# Altium schematic sheet 04_fmu_mcu1.SchDoc(04_fmu_mcu1)
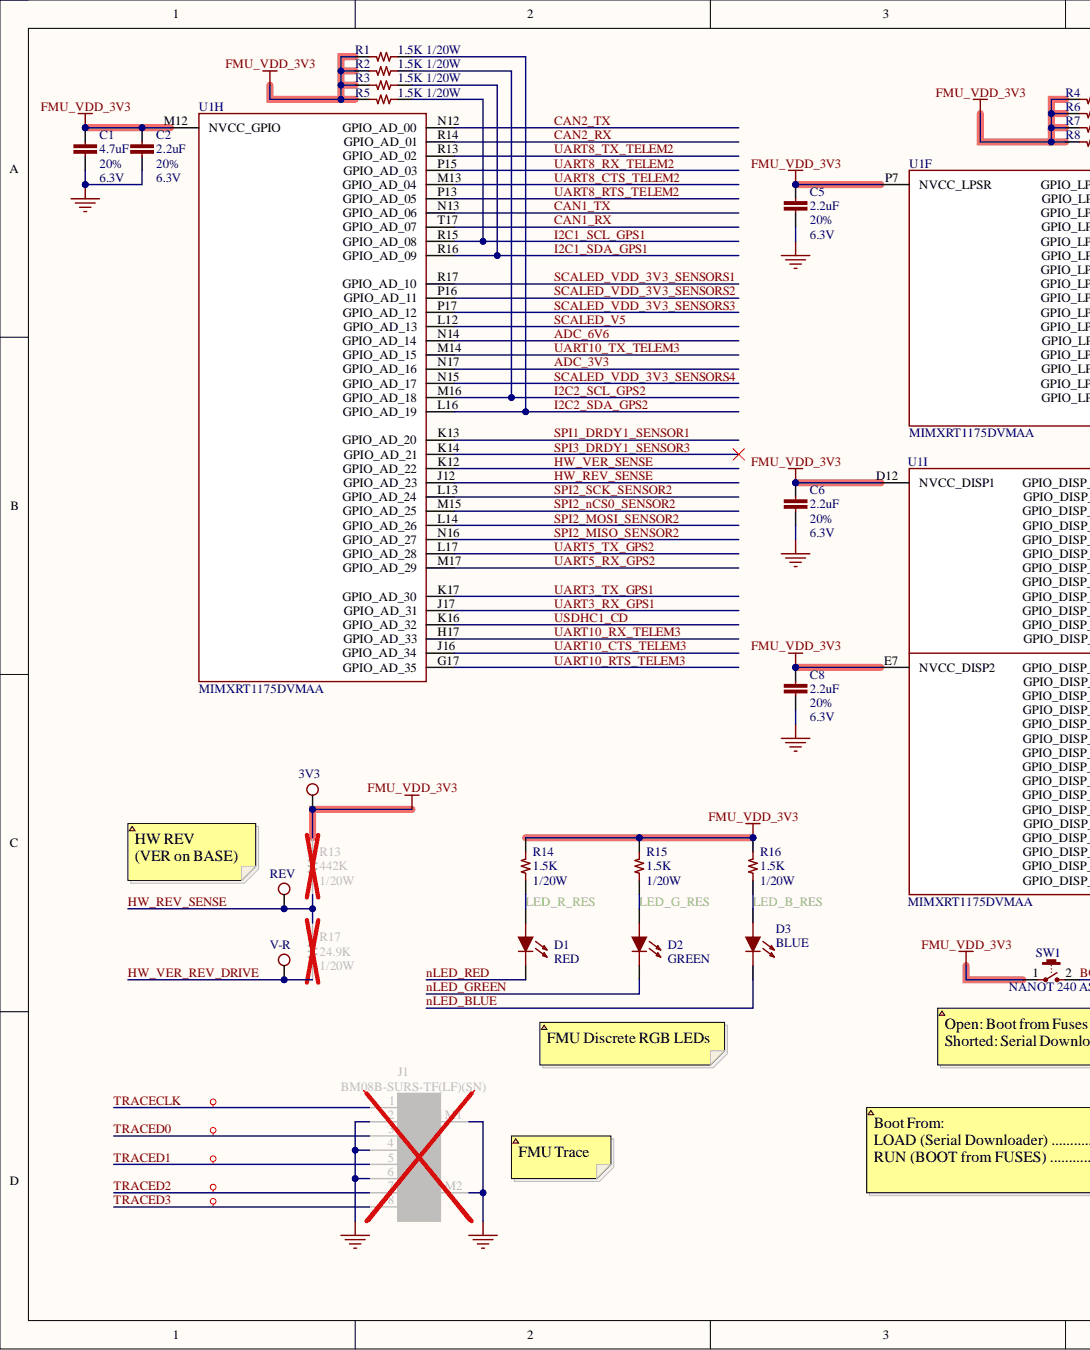
[diagram p0: 04_fmu_mcu1.SchDoc, left]
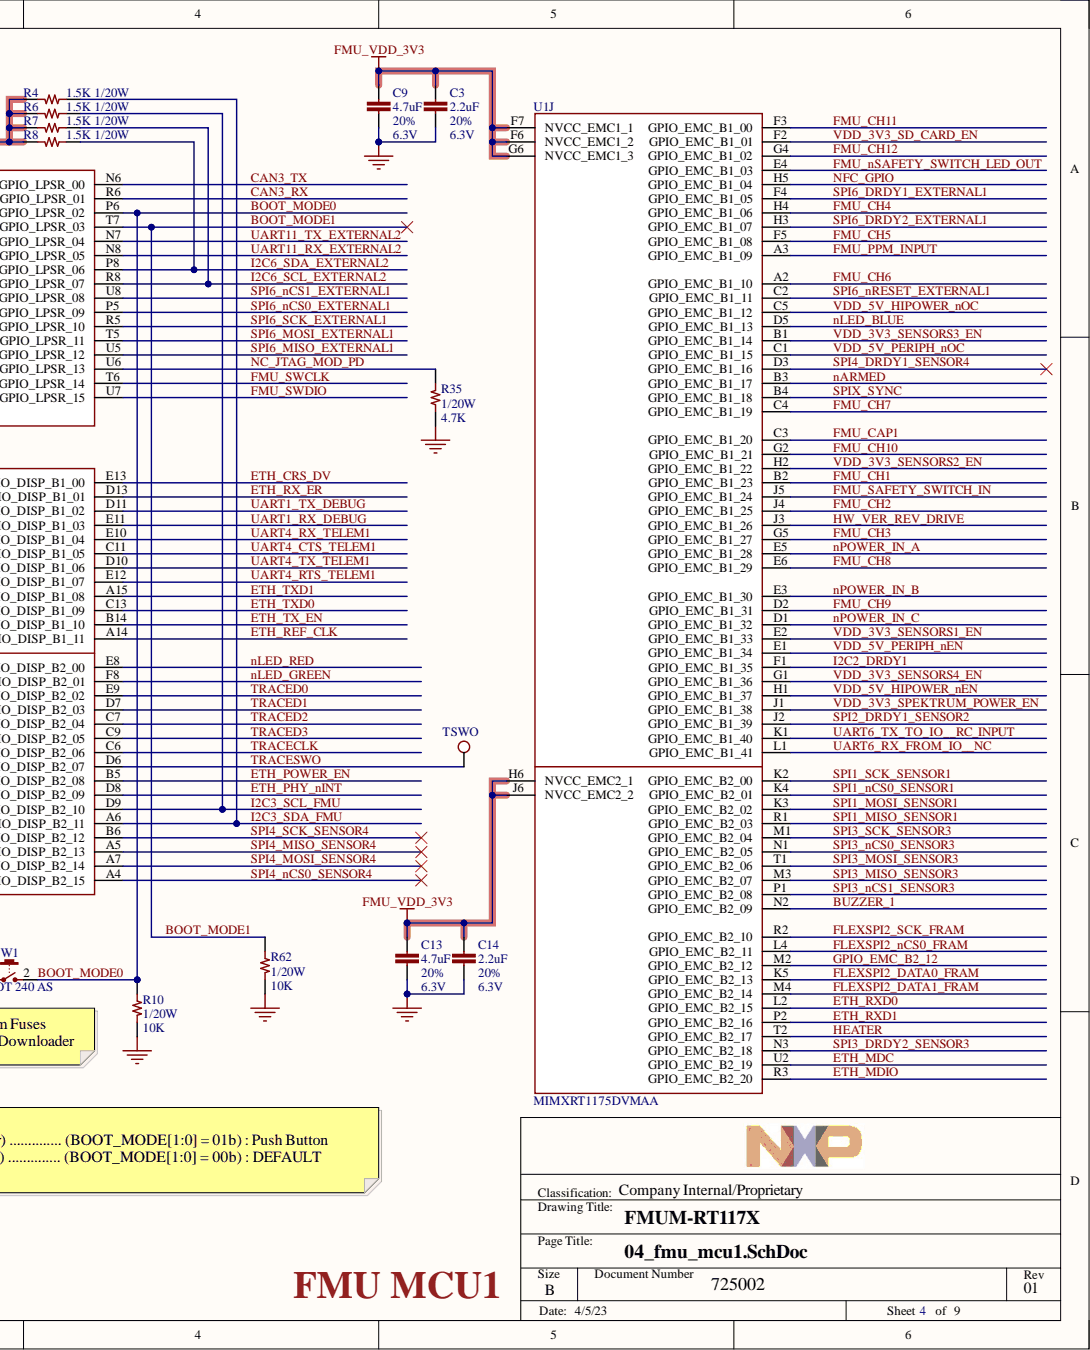
[diagram p1: 04_fmu_mcu1.SchDoc, right]

## Parts
3V3  TESTPOINT PAD SMD  [TEST_PAD_1MM]  (value not drawn)  (no BOM)
C1  value 4.7uF  Tolerance: 20%  Voltage - Rated: 6.3V  [CAPC60X30X33L15N]  (hidden comment: CAP CER 4.7uF 20% 10V X5R 0201)
C2  value 2.2uF  Tolerance: 20%  Voltage - Rated: 6.3V  [CAPC60X30X33L15N]  (hidden comment: CAP CER 2.2uF 20% 10V X5R 0201)
C3  value 2.2uF  Tolerance: 20%  Voltage - Rated: 6.3V  [CAPC60X30X33L15N]  (hidden comment: CAP CER 2.2uF 20% 10V X5R 0201)
C5  value 2.2uF  Tolerance: 20%  Voltage - Rated: 6.3V  [CAPC60X30X33L15N]  (hidden comment: CAP CER 2.2uF 20% 10V X5R 0201)
C6  value 2.2uF  Tolerance: 20%  Voltage - Rated: 6.3V  [CAPC60X30X33L15N]  (hidden comment: CAP CER 2.2uF 20% 10V X5R 0201)
C8  value 2.2uF  Tolerance: 20%  Voltage - Rated: 6.3V  [CAPC60X30X33L15N]  (hidden comment: CAP CER 2.2uF 20% 10V X5R 0201)
C9  value 4.7uF  Tolerance: 20%  Voltage - Rated: 6.3V  [CAPC60X30X33L15N]  (hidden comment: CAP CER 4.7uF 20% 10V X5R 0201)
C13  value 4.7uF  Tolerance: 20%  Voltage - Rated: 6.3V  [CAPC60X30X33L15N]  (hidden comment: CAP CER 4.7uF 20% 10V X5R 0201)
C14  value 2.2uF  Tolerance: 20%  Voltage - Rated: 6.3V  [CAPC60X30X33L15N]  (hidden comment: CAP CER 2.2uF 20% 10V X5R 0201)
D1  Color: RED  [LEDSC80X160X65L40N_RED]  (hidden comment: LED RED CLEAR 0603 SMD)
D2  Color: GREEN  [LEDSC80X160X65L40N_GRN]  (hidden comment: LED GREEN CLEAR 0603 SMD)
D3  Color: BLUE  [LEDSC80X160X65L40N_BLU]  (hidden comment: LED BLUE CLEAR 0603 SMD)
J1  Manufacturer Part Number: BM08B-SURS-TF(LF)(SN)  [JST_BM08B-SURS-TFLFSN]  (hidden comment: CONN HEADER SUR 8POS .8MM VERT SMT)
R1  value 1.5K  Power: 1/20W  [RESC60X30X33L15N]  (hidden comment: RES SMD 1.5K OHM 1% 1/20W 0201)
R2  value 1.5K  Power: 1/20W  [RESC60X30X33L15N]  (hidden comment: RES SMD 1.5K OHM 1% 1/20W 0201)
R3  value 1.5K  Power: 1/20W  [RESC60X30X33L15N]  (hidden comment: RES SMD 1.5K OHM 1% 1/20W 0201)
R4  value 1.5K  Power: 1/20W  [RESC60X30X33L15N]  (hidden comment: RES SMD 1.5K OHM 1% 1/20W 0201)
R5  value 1.5K  Power: 1/20W  [RESC60X30X33L15N]  (hidden comment: RES SMD 1.5K OHM 1% 1/20W 0201)
R6  value 1.5K  Power: 1/20W  [RESC60X30X33L15N]  (hidden comment: RES SMD 1.5K OHM 1% 1/20W 0201)
R7  value 1.5K  Power: 1/20W  [RESC60X30X33L15N]  (hidden comment: RES SMD 1.5K OHM 1% 1/20W 0201)
R8  value 1.5K  Power: 1/20W  [RESC60X30X33L15N]  (hidden comment: RES SMD 1.5K OHM 1% 1/20W 0201)
R10  value 10K  Power: 1/20W  [RESC60X30X33L15N]  (hidden comment: RES SMD 10K OHM 1% 1/20W 0201)
R13  value 442K  Power: 1/20W  [RESC60X30X33L15N]  (hidden comment: RES SMD 442K OHM 1% 1/20W 0201)
R14  value 1.5K  Power: 1/20W  [RESC60X30X33L15N]  (hidden comment: RES SMD 1.5K OHM 1% 1/20W 0201)
R15  value 1.5K  Power: 1/20W  [RESC60X30X33L15N]  (hidden comment: RES SMD 1.5K OHM 1% 1/20W 0201)
R16  value 1.5K  Power: 1/20W  [RESC60X30X33L15N]  (hidden comment: RES SMD 1.5K OHM 1% 1/20W 0201)
R17  value 24.9K  Power: 1/20W  [RESC60X30X33L15N]  (hidden comment: RES SMD 24.9K OHM 1% 1/20W 0201)
R35  value 4.7K  Power: 1/20W  [RESC60X30X33L15N]  (hidden comment: RES SMD 4.7K OHM 1% 1/20W 0201)
R62  value 10K  Power: 1/20W  [RESC60X30X33L15N]  (hidden comment: RES SMD 10K OHM 1% 1/20W 0201)
REV  TESTPOINT PAD SMD  [TEST_PAD_1MM]  (value not drawn)  (no BOM)
SW1  Manufacturer Part Number: NANOT 240 AS  [C&K_NANOT_240_AS]  (hidden comment: SWITCH SPST-NO OFF-MOM 0.02A 15V 240GF SMT)
TSWO  TESTPOINT PAD SMD  [TEST_PAD_1MM]  (value not drawn)  (no BOM)
U1  Manufacturer Part Number: MIMXRT1175DVMAA  [BGA289CP80_17X17_1400X1400X152B43N]  (hidden comment: IC MCU 32BIT EXT MEM 800MHz 289MAPBGA)  (parts F,H,I,J)
V-R  TESTPOINT PAD SMD  [TEST_PAD_1MM]  (value not drawn)  (no BOM)

## Nets
FMU_VDD_3V3: 3V3.1 C1.1 C2.1 C3.1 C5.1 C6.1 C8.1 C9.1 C13.1 C14.1 R1.1 R2.1 R3.1 R4.1 R5.1 R6.1 R7.1 R8.1 R13.1 R14.1 R15.1 R16.1 SW1.1 U1.D12(NVCC_DISP1) U1.E7(NVCC_DISP2) U1.F6(NVCC_EMC1_2) U1.F7(NVCC_EMC1_1) U1.G6(NVCC_EMC1_3) U1.H6(NVCC_EMC2_1) U1.J6(NVCC_EMC2_2) U1.M12(NVCC_GPIO) U1.P7(NVCC_LPSR)
GND: C1.2 C2.2 C3.2 C5.2 C6.2 C8.2 C9.2 C13.2 C14.2 J1.2 J1.4 J1.6 J1.M1 J1.M2 R10.1 R35.2 R62.1
ADC_3V3: U1.N17(GPIO_AD_16)
ADC_6V6: U1.N14(GPIO_AD_14)
BOOT_MODE0: R10.2 SW1.2 U1.P6(GPIO_LPSR_02)
BOOT_MODE1: R62.2 U1.T7(GPIO_LPSR_03)
BUZZER_1: U1.N2(GPIO_EMC_B2_09)
CAN1_RX: U1.T17(GPIO_AD_07)
CAN1_TX: U1.N13(GPIO_AD_06)
CAN2_RX: U1.R14(GPIO_AD_01)
CAN2_TX: U1.N12(GPIO_AD_00)
CAN3_RX: U1.R6(GPIO_LPSR_01)
CAN3_TX: U1.N6(GPIO_LPSR_00)
ETH_CRS_DV: U1.E13(GPIO_DISP_B1_00)
ETH_MDC: U1.U2(GPIO_EMC_B2_19)
ETH_MDIO: U1.R3(GPIO_EMC_B2_20)
ETH_PHY_nINT: U1.D8(GPIO_DISP_B2_09)
ETH_POWER_EN: U1.B5(GPIO_DISP_B2_08)
ETH_REF_CLK: U1.A14(GPIO_DISP_B1_11)
ETH_RX_ER: U1.D13(GPIO_DISP_B1_01)
ETH_RXD0: U1.L2(GPIO_EMC_B2_15)
ETH_RXD1: U1.P2(GPIO_EMC_B2_16)
ETH_TX_EN: U1.B14(GPIO_DISP_B1_10)
ETH_TXD0: U1.C13(GPIO_DISP_B1_09)
ETH_TXD1: U1.A15(GPIO_DISP_B1_08)
FLEXSPI2_DATA0_FRAM: U1.K5(GPIO_EMC_B2_13)
FLEXSPI2_DATA1_FRAM: U1.M4(GPIO_EMC_B2_14)
FLEXSPI2_nCS0_FRAM: U1.L4(GPIO_EMC_B2_11)
FLEXSPI2_SCK_FRAM: U1.R2(GPIO_EMC_B2_10)
FMU_CAP1: U1.C3(GPIO_EMC_B1_20)
FMU_CH1: U1.B2(GPIO_EMC_B1_23)
FMU_CH2: U1.J4(GPIO_EMC_B1_25)
FMU_CH3: U1.G5(GPIO_EMC_B1_27)
FMU_CH4: U1.H4(GPIO_EMC_B1_06)
FMU_CH5: U1.F5(GPIO_EMC_B1_08)
FMU_CH6: U1.A2(GPIO_EMC_B1_10)
FMU_CH7: U1.C4(GPIO_EMC_B1_19)
FMU_CH8: U1.E6(GPIO_EMC_B1_29)
FMU_CH9: U1.D2(GPIO_EMC_B1_31)
FMU_CH10: U1.G2(GPIO_EMC_B1_21)
FMU_CH11: U1.F3(GPIO_EMC_B1_00)
FMU_CH12: U1.G4(GPIO_EMC_B1_02)
FMU_nSAFETY_SWITCH_LED_OUT: U1.E4(GPIO_EMC_B1_03)
FMU_PPM_INPUT: U1.A3(GPIO_EMC_B1_09)
FMU_SAFETY_SWITCH_IN: U1.J5(GPIO_EMC_B1_24)
FMU_SWCLK: U1.T6(GPIO_LPSR_14)
FMU_SWDIO: U1.U7(GPIO_LPSR_15)
GPIO_EMC_B2_12: U1.M2(GPIO_EMC_B2_12)
HEATER: U1.T2(GPIO_EMC_B2_17)
HW_REV_SENSE: R13.2 R17.1 REV.1 U1.J12(GPIO_AD_23)
HW_VER_REV_DRIVE: R17.2 U1.J3(GPIO_EMC_B1_26) V-R.1
HW_VER_SENSE: U1.K12(GPIO_AD_22)
I2C1_SCL_GPS1: R5.2 U1.R15(GPIO_AD_08)
I2C1_SDA_GPS1: R3.2 U1.R16(GPIO_AD_09)
I2C2_DRDY1: U1.F1(GPIO_EMC_B1_35)
I2C2_SCL_GPS2: R2.2 U1.M16(GPIO_AD_18)
I2C2_SDA_GPS2: R1.2 U1.L16(GPIO_AD_19)
I2C3_SCL_FMU: R6.2 U1.D9(GPIO_DISP_B2_10)
I2C3_SDA_FMU: R4.2 U1.A6(GPIO_DISP_B2_11)
I2C6_SCL_EXTERNAL2: R7.2 U1.R8(GPIO_LPSR_07)
I2C6_SDA_EXTERNAL2: R8.2 U1.P8(GPIO_LPSR_06)
LED_B_RES: D3.A R16.2
LED_G_RES: D2.A R15.2
LED_R_RES: D1.A R14.2
nARMED: U1.B3(GPIO_EMC_B1_17)
NC_JTAG_MOD_PD: R35.1 U1.U6(GPIO_LPSR_13)
NFC_GPIO: U1.H5(GPIO_EMC_B1_04)
nLED_BLUE: D3.C U1.D5(GPIO_EMC_B1_13)
nLED_GREEN: D2.C U1.F8(GPIO_DISP_B2_01)
nLED_RED: D1.C U1.E8(GPIO_DISP_B2_00)
nPOWER_IN_A: U1.E5(GPIO_EMC_B1_28)
nPOWER_IN_B: U1.E3(GPIO_EMC_B1_30)
nPOWER_IN_C: U1.D1(GPIO_EMC_B1_32)
SCALED_V5: U1.L12(GPIO_AD_13)
SCALED_VDD_3V3_SENSORS1: U1.R17(GPIO_AD_10)
SCALED_VDD_3V3_SENSORS2: U1.P16(GPIO_AD_11)
SCALED_VDD_3V3_SENSORS3: U1.P17(GPIO_AD_12)
SCALED_VDD_3V3_SENSORS4: U1.N15(GPIO_AD_17)
SPI1_DRDY1_SENSOR1: U1.K13(GPIO_AD_20)
SPI1_MISO_SENSOR1: U1.R1(GPIO_EMC_B2_03)
SPI1_MOSI_SENSOR1: U1.K3(GPIO_EMC_B2_02)
SPI1_nCS0_SENSOR1: U1.K4(GPIO_EMC_B2_01)
SPI1_SCK_SENSOR1: U1.K2(GPIO_EMC_B2_00)
SPI2_DRDY1_SENSOR2: U1.J2(GPIO_EMC_B1_39)
SPI2_MISO_SENSOR2: U1.N16(GPIO_AD_27)
SPI2_MOSI_SENSOR2: U1.L14(GPIO_AD_26)
SPI2_nCS0_SENSOR2: U1.M15(GPIO_AD_25)
SPI2_SCK_SENSOR2: U1.L13(GPIO_AD_24)
SPI3_DRDY1_SENSOR3: U1.K14(GPIO_AD_21)
SPI3_DRDY2_SENSOR3: U1.N3(GPIO_EMC_B2_18)
SPI3_MISO_SENSOR3: U1.M3(GPIO_EMC_B2_07)
SPI3_MOSI_SENSOR3: U1.T1(GPIO_EMC_B2_06)
SPI3_nCS0_SENSOR3: U1.N1(GPIO_EMC_B2_05)
SPI3_nCS1_SENSOR3: U1.P1(GPIO_EMC_B2_08)
SPI3_SCK_SENSOR3: U1.M1(GPIO_EMC_B2_04)
SPI4_DRDY1_SENSOR4: U1.D3(GPIO_EMC_B1_16)
SPI4_MISO_SENSOR4: U1.A5(GPIO_DISP_B2_13)
SPI4_MOSI_SENSOR4: U1.A7(GPIO_DISP_B2_14)
SPI4_nCS0_SENSOR4: U1.A4(GPIO_DISP_B2_15)
SPI4_SCK_SENSOR4: U1.B6(GPIO_DISP_B2_12)
SPI6_DRDY1_EXTERNAL1: U1.F4(GPIO_EMC_B1_05)
SPI6_DRDY2_EXTERNAL1: U1.H3(GPIO_EMC_B1_07)
SPI6_MISO_EXTERNAL1: U1.U5(GPIO_LPSR_12)
SPI6_MOSI_EXTERNAL1: U1.T5(GPIO_LPSR_11)
SPI6_nCS0_EXTERNAL1: U1.P5(GPIO_LPSR_09)
SPI6_nCS1_EXTERNAL1: U1.U8(GPIO_LPSR_08)
SPI6_nRESET_EXTERNAL1: U1.C2(GPIO_EMC_B1_11)
SPI6_SCK_EXTERNAL1: U1.R5(GPIO_LPSR_10)
SPIX_SYNC: U1.B4(GPIO_EMC_B1_18)
TRACECLK: J1.1 U1.C6(GPIO_DISP_B2_06)
TRACED0: J1.3 U1.E9(GPIO_DISP_B2_02)
TRACED1: J1.5 U1.D7(GPIO_DISP_B2_03)
TRACED2: J1.7 U1.C7(GPIO_DISP_B2_04)
TRACED3: J1.8 U1.C9(GPIO_DISP_B2_05)
TRACESWO: TSWO.1 U1.D6(GPIO_DISP_B2_07)
UART1_RX_DEBUG: U1.E11(GPIO_DISP_B1_03)
UART1_TX_DEBUG: U1.D11(GPIO_DISP_B1_02)
UART3_RX_GPS1: U1.J17(GPIO_AD_31)
UART3_TX_GPS1: U1.K17(GPIO_AD_30)
UART4_CTS_TELEM1: U1.C11(GPIO_DISP_B1_05)
UART4_RTS_TELEM1: U1.E12(GPIO_DISP_B1_07)
UART4_RX_TELEM1: U1.E10(GPIO_DISP_B1_04)
UART4_TX_TELEM1: U1.D10(GPIO_DISP_B1_06)
UART5_RX_GPS2: U1.M17(GPIO_AD_29)
UART5_TX_GPS2: U1.L17(GPIO_AD_28)
UART6_RX_FROM_IO__NC: U1.L1(GPIO_EMC_B1_41)
UART6_TX_TO_IO__RC_INPUT: U1.K1(GPIO_EMC_B1_40)
UART8_CTS_TELEM2: U1.M13(GPIO_AD_04)
UART8_RTS_TELEM2: U1.P13(GPIO_AD_05)
UART8_RX_TELEM2: U1.P15(GPIO_AD_03)
UART8_TX_TELEM2: U1.R13(GPIO_AD_02)
UART10_CTS_TELEM3: U1.J16(GPIO_AD_34)
UART10_RTS_TELEM3: U1.G17(GPIO_AD_35)
UART10_RX_TELEM3: U1.H17(GPIO_AD_33)
UART10_TX_TELEM3: U1.M14(GPIO_AD_15)
UART11_RX_EXTERNAL2: U1.N8(GPIO_LPSR_05)
UART11_TX_EXTERNAL2: U1.N7(GPIO_LPSR_04)
USDHC1_CD: U1.K16(GPIO_AD_32)
VDD_3V3_SD_CARD_EN: U1.F2(GPIO_EMC_B1_01)
VDD_3V3_SENSORS1_EN: U1.E2(GPIO_EMC_B1_33)
VDD_3V3_SENSORS2_EN: U1.H2(GPIO_EMC_B1_22)
VDD_3V3_SENSORS3_EN: U1.B1(GPIO_EMC_B1_14)
VDD_3V3_SENSORS4_EN: U1.G1(GPIO_EMC_B1_36)
VDD_3V3_SPEKTRUM_POWER_EN: U1.J1(GPIO_EMC_B1_38)
VDD_5V_HIPOWER_nEN: U1.H1(GPIO_EMC_B1_37)
VDD_5V_HIPOWER_nOC: U1.C5(GPIO_EMC_B1_12)
VDD_5V_PERIPH_nEN: U1.E1(GPIO_EMC_B1_34)
VDD_5V_PERIPH_nOC: U1.C1(GPIO_EMC_B1_15)

## Library data of the parts
3V3 REV TSWO V-R = TESTPOINT_PAD_SMD: CATEGORY: Test and Measurement; Description: TESTPOINT PAD SMD; Manufacturer: N/A; Library Name: NscDG_Integrated_Library.SchLib
C1 C9 C13 = CAP_4.7uF_6.3V_X5R_0201: Manufacturer: Murata Electronics; Manufacturer Part Number: GRM035R60J475ME15D; Category: Capacitors; Component Kind: Standard; ComponentLink1Description: https://www.digikey.com/en/products/detail/murata-electronics/GRM035R60J475ME15D/5877393; ComponentLink1URL: https://www.digikey.com/en/products/detail/murata-electronics/GRM035R60J475ME15D/5877393; Description: CAP CER 4.7uF 20% 10V X5R 0201; Operating Temperature: -55°C ~ 85°C; Packaging: Cut Tape (CT); Part Status: Active; Pricing 1: 1=0.24 (USD); Stock 1: 73400; Supplier 1: Digi-Key; Supplier Part Number 1: 490-13230-1-ND; Library Name: NscDG_Integrated_Library.SchLib
C2 C3 C5 C6 C8 C14 = CAP_2.2uF_6.3V_X5R_0201: Manufacturer: Murata Electronics; Manufacturer Part Number: GRM033R60J225ME47D; Category: Capacitors; Component Kind: Standard; ComponentLink1Description: https://www.digikey.com/en/products/detail/murata-electronics/GRM033R60J225ME47D/5877388; ComponentLink1URL: https://www.digikey.com/en/products/detail/murata-electronics/GRM033R60J225ME47D/5877388; Description: CAP CER 2.2uF 20% 10V X5R 0201; Operating Temperature: -55°C ~ 85°C; Packaging: Cut Tape (CT); Part Status: Active; Pricing 1: 1=0.18 (USD); Stock 1: 9156088; Supplier 1: Digi-Key; Supplier Part Number 1: 490-13225-1-ND; Library Name: NscDG_Integrated_Library.SchLib
D1 = DIODE_LED_RED_0603: Manufacturer: Lite-On Inc.; Manufacturer Part Number: LTST-C191KRKT; Category: Optoelectronics; Component Kind: Standard; ComponentLink1Description: http://optoelectronics.liteon.com/upload/download/DS22-2000-228/LTST-C191KGKT.PDF; ComponentLink1URL: http://optoelectronics.liteon.com/upload/download/DS22-2000-228/LTST-C191KGKT.PDF; ComponentLink2Description: https://www.digikey.com/product-detail/en/lite-on-inc/LTST-C191KRKT/160-1447-1-ND/386836; ComponentLink2URL: https://www.digikey.com/product-detail/en/lite-on-inc/LTST-C191KRKT/160-1447-1-ND/386836; Description: LED RED CLEAR 0603 SMD; Packaging: Cut Tape (CT); Part Status: Active; Pricing 1: 1=0.29, 10=0.211, 25=0.1556, 100=0.1111 (USD); Stock 1: 1010580; Supplier 1: Digi-Key; Supplier Part Number 1: 160-1447-1-ND; Library Name: NscDG_Integrated_Library.SchLib
D2 = DIODE_LED_GREEN_0603: Manufacturer: Lite-On Inc.; Manufacturer Part Number: LTST-C191KGKT; Category: Optoelectronics; Component Kind: Standard; ComponentLink1Description: http://optoelectronics.liteon.com/upload/download/DS22-2000-228/LTST-C191KGKT.PDF; ComponentLink1URL: http://optoelectronics.liteon.com/upload/download/DS22-2000-228/LTST-C191KGKT.PDF; ComponentLink2Description: https://www.digikey.com/product-detail/en/lite-on-inc/LTST-C191KGKT/160-1446-1-ND/386834; ComponentLink2URL: https://www.digikey.com/product-detail/en/lite-on-inc/LTST-C191KGKT/160-1446-1-ND/386834; Description: LED GREEN CLEAR 0603 SMD; Packaging: Cut Tape (CT); Part Status: Active; Pricing 1: 1=0.34, 10=0.253, 25=0.1872, 100=0.1353 (USD); Stock 1: 2166001; Supplier 1: Digi-Key; Supplier Part Number 1: 160-1446-1-ND; Library Name: NscDG_Integrated_Library.SchLib
D3 = DIODE_LED_BLUE_0603: Manufacturer: Lite-On Inc.; Manufacturer Part Number: LTST-C191TBKT; Category: Optoelectronics; Component Kind: Standard; ComponentLink1Description: http://optoelectronics.liteon.com/upload/download/DS22-2000-228/LTST-C191KGKT.PDF; ComponentLink1URL: http://optoelectronics.liteon.com/upload/download/DS22-2000-228/LTST-C191KGKT.PDF; ComponentLink2Description: https://www.digikey.com/product-detail/en/lite-on-inc/LTST-C191TBKT/160-1647-1-ND/573587; ComponentLink2URL: https://www.digikey.com/product-detail/en/lite-on-inc/LTST-C191TBKT/160-1647-1-ND/573587; Description: LED BLUE CLEAR 0603 SMD; Packaging: Cut Tape (CT); Part Status: Active; Pricing 1: 1=0.53, 10=0.384, 25=0.2964, 100=0.196 (USD); Stock 1: 403566; Supplier 1: Digi-Key; Supplier Part Number 1: 160-1647-1-ND; Library Name: NscDG_Integrated_Library.SchLib
J1 = CONN_JST_SUR_8PIN_VERT_SMT: Manufacturer: JST Sales America Inc.; System: Connector; Voltage - Rated: 30V; Category: Connectors, Interconnects; Component Kind: Standard; ComponentLink1Description: https://www.digikey.com/products/en/connectors-interconnects/rectangular-connectors-headers-male-pins/314?k=bm08bsur&k=&pkeyword=bm08bsur&sv=0&pv7=2&sf=0&quantity=&ColumnSort=0&page=1&pageSize=25; ComponentLink1URL: https://www.digikey.com/products/en/connectors-interconnects/rectangular-connectors-headers-male-pins/314?k=bm08bsur&k=&pkeyword=bm08bsur&sv=0&pv7=2&sf=0&quantity=&ColumnSort=0&page=1&pageSize=25; Description: CONN HEADER SUR 8POS .8MM VERT SMT; Operating Temperature: -25°C ~ 85°C; Packaging: Tape & Reel (TR); Part Status: Active; Pricing 1: 1=0.73 (USD); Stock 1: 2227; Supplier 1: Digi-Key; Supplier Part Number 1: 455-3578-1-ND; Library Name: NscDG_Integrated_Library.SchLib
R1-R8 R14-R16 = RES_1.5K_1%_1/20W_0201: Manufacturer: Yageo; Manufacturer Part Number: RC0201FR-071K5L; Category: Resistors; Component Kind: Standard; ComponentLink1Description: https://www.digikey.com/en/products/detail/yageo/RC0201FR-071K5L/3202387; ComponentLink1URL: https://www.digikey.com/en/products/detail/yageo/RC0201FR-071K5L/3202387; Description: RES SMD 1.5K OHM 1% 1/20W 0201; Operating Temperature: -55°C ~ 125°C; Packaging: Cut Tape (CT); Part Status: Active; Pricing 1: 1=0.1, 10=0.014, 100=0.0056, 1000=0.00253, 2500=0.0022, 5000=0.00181 (USD); Stock 1: 450236; Supplier 1: Digi-Key; Supplier Part Number 1: YAG2274CT-ND; Tolerance: ±1%; Library Name: NscDG_Integrated_Library.SchLib
R10 R62 = RES_10K_1%_1/20W_0201: Manufacturer: Yageo; Manufacturer Part Number: AC0201FR-0710KL; Category: Resistors; Component Kind: Standard; ComponentLink1Description: https://www.digikey.com/en/products/detail/yageo/AC0201FR-0710KL/5894228; ComponentLink1URL: https://www.digikey.com/en/products/detail/yageo/AC0201FR-0710KL/5894228; Description: RES SMD 10K OHM 1% 1/20W 0201; Operating Temperature: -55°C ~ 125°C; Packaging: Cut Tape (CT); Part Status: Active; Pricing 1: 1=0.1, 10=0.014, 100=0.0056, 1000=0.00253, 2500=0.0022, 5000=0.00181 (USD); Stock 1: 739618; Supplier 1: Digi-Key; Supplier Part Number 1: YAG3413CT-ND; Tolerance: ±1%; Library Name: NscDG_Integrated_Library.SchLib
R13 = RES_442K_1%_1/20W_0201: Manufacturer: Yageo; Manufacturer Part Number: RC0201FR-07442KL; Category: Resistors; Component Kind: Standard; ComponentLink1Description: https://www.digikey.com/en/products/detail/yageo/RC0201FR-07442KL/5280536; ComponentLink1URL: https://www.digikey.com/en/products/detail/yageo/RC0201FR-07442KL/5280536; Description: RES SMD 442K OHM 1% 1/20W 0201; Operating Temperature: -55°C ~ 125°C; Packaging: Cut Tape (CT); Part Status: Active; Pricing 1: 1=0.1, 10=0.014, 100=0.0056, 1000=0.00253, 2500=0.0022, 5000=0.00181 (USD); Stock 1: 21487; Supplier 1: Digi-Key; Supplier Part Number 1: YAG2676CT-ND; Tolerance: ±1%; Library Name: NscDG_Integrated_Library.SchLib
R17 = RES_24.9K_1%_1/20W_0201: Manufacturer: Yageo; Manufacturer Part Number: RC0201FR-0724K9L; Category: Resistors; Component Kind: Standard; ComponentLink1Description: https://www.digikey.com/en/products/detail/yageo/RC0201FR-0724K9L/3202396; ComponentLink1URL: https://www.digikey.com/en/products/detail/yageo/RC0201FR-0724K9L/3202396; Description: RES SMD 24.9K OHM 1% 1/20W 0201; Operating Temperature: -55°C ~ 125°C; Packaging: Cut Tape (CT); Part Status: Active; Pricing 1: 1=0.1, 10=0.014, 100=0.0056, 1000=0.00253, 2500=0.0022, 5000=0.00181 (USD); Stock 1: 328589; Supplier 1: Digi-Key; Supplier Part Number 1: YAG2279CT-ND; Tolerance: ±1%; Library Name: NscDG_Integrated_Library.SchLib
R35 = RES_4.7K_1%_1/20W_0201: Manufacturer: Yageo; Manufacturer Part Number: RC0201FR-074K7L; Category: Resistors; Component Kind: Standard; ComponentLink1Description: https://www.digikey.com/en/products/detail/yageo/RC0201FR-074K7L/1948894; ComponentLink1URL: https://www.digikey.com/en/products/detail/yageo/RC0201FR-074K7L/1948894; Description: RES SMD 4.7K OHM 1% 1/20W 0201; Operating Temperature: -55°C ~ 125°C; Packaging: Cut Tape (CT); Part Status: Active; Pricing 1: 1=0.1, 10=0.014, 100=0.0056, 1000=0.00253, 2500=0.0022, 5000=0.00181 (USD); Stock 1: 6201845; Supplier 1: Digi-Key; Supplier Part Number 1: 311-4.70KMCT-ND; Tolerance: ±1%; Library Name: NscDG_Integrated_Library.SchLib
SW1 = SWITCH_SPST_NANO_OFF_MOM_NO_SMT: Manufacturer: C&K; Voltage - Rated: 0.02A @ 15VDC; Category: Switches; Component Kind: Standard; ComponentLink1Description: https://www.digikey.com/en/products/detail/c-k/NANOT-240-AS/13182173; ComponentLink1URL: https://www.digikey.com/en/products/detail/c-k/NANOT-240-AS/13182173; Description: SWITCH SPST-NO OFF-MOM 0.02A 15V 240GF SMT; Operating Temperature: -40°C ~ 85°C; Packaging: Cut Tape (CT); Part Status: Active; Pricing 1: 1=0.43 (USD); Stock 1: 7731; Supplier 1: Digi-Key; Supplier Part Number 1: 108-NANOT240ASCT-ND; Library Name: NscDG_Integrated_Library.SchLib
U1 = IC_MIMXRT1175DVMAA_289MAPBGA: Manufacturer: NXP USA; Category: Integrated Circuits (ICs); Component Kind: Standard; ComponentLink1Description: https://www.digikey.com/en/products/detail/nxp-usa-inc/MIMXRT1062DVL6B/13635843?s=N4IgTCBcDaILIEk4A0BKAVAjABgGxgBEA1AGVwCEQBdAXyA; ComponentLink1URL: https://www.digikey.com/en/products/detail/nxp-usa-inc/MIMXRT1175DVMAA/13536094?s=N4IgTCBcDaIJIFkAaAlAKgRgwdgKwBEA1BAQRJAF0BfIA; Description: IC MCU 32BIT EXT MEM 800MHz 289MAPBGA; Operating Temperature: 0C to 95C; Packaging: Tray; Part Status: Active; Pricing 1: 1=17.93 (USD); Stock 1: 0; Supplier 1: DigiKey; Supplier Part Number 1: 568-MIMXRT1175DVMAA-ND; Library Name: NscDG_Integrated_Library.SchLib
